# Altium schematic sheet ATMega328P_weather_station_MCU.SchDoc(ATMega328P_weather_station_MCU)
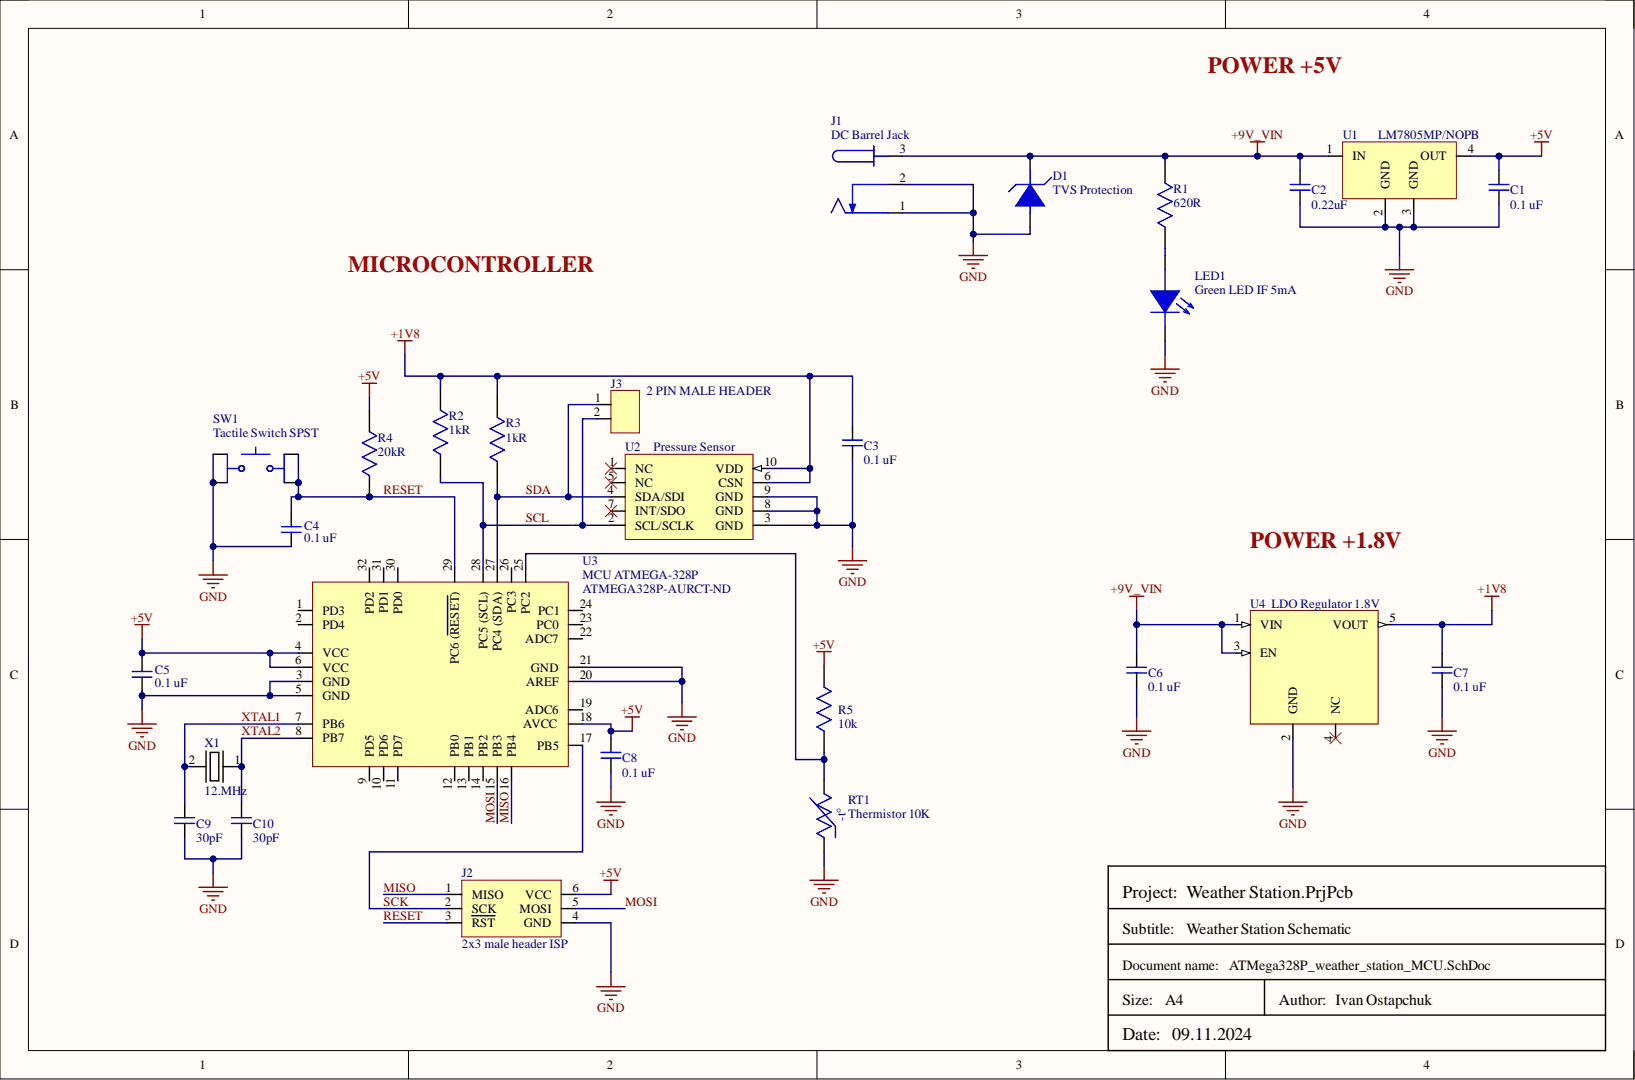
[diagram p0: ATMega328P_weather_station_MCU.SchDoc]

## Parts
C1  0.1 uF  [1210B104K500CT]
C2  0.22uF  [Ceramic Cap 0.22uF 1210 Low density]
C3  0.1 uF  [1210B104K500CT]
C4  0.1 uF  [1210B104K500CT]
C5  0.1 uF  [1210B104K500CT]
C6  0.1 uF  [1210B104K500CT]
C7  0.1 uF  [1210B104K500CT]
C8  0.1 uF  [1210B104K500CT]
C9  30pF  [Kyocera 1210 Ceramic Capacitor]
C10  30pF  [Kyocera 1210 Ceramic Capacitor]
D1  TVS Protection  [Unidirectional TVS protection diode]
J1  DC Barrel Jack  [Power Barrel Jack]
J2  2x3 male header ISP  [2x3 male header ISP]
J3  2 PIN MALE HEADER  [2 pin male header smd]
LED1  Green LED IF 5mA  [SMD LED]
R1  620R  [1206 WIDE SMD Resistor]
R2  1kR  [Yageo 1206 SMD Resistor]
R3  1kR  [Yageo 1206 SMD Resistor]
R4  20kR  [Yageo 1206 SMD Resistor]
R5  10k  [Yageo 1206 SMD Resistor]
RT1  Thermistor 10K  [Yageo 1206 SMD Resistor]
SW1  Tactile Switch SPST  Throw Configuration: SPST  [Tactile Switch]
U1  Linear V Regulator  [LM7805MP/NOPB]  (value not drawn)
U2  Pressure Sensor  [XDCR_ENS220S-BLGT]
U3  MCU ATMEGA-328P  Supplier Part Number 1: ATMEGA328P-AURCT-ND  [QFP80P900X900X120-32N]
U4  LDO Regulator 1.8V  [LDO 1.8V]
X1  12.MHz  [ABLS-12.000MHZ-20-B-3-H-T]

## Nets
+1V8: C3.2 C7.1 R2.1 R3.1 U2.6(CSN) U2.10(VDD) U4.5(VOUT)
+5V: C1.1 C5.2 C8.2 J2.6(VCC) R4.1 R5.1 U1.4(OUT) U3.4(VCC) U3.6(VCC) U3.18(AVCC)
+9V_VIN: C2.1 C6.1 D1.2 J1.3 R1.2 U1.1(IN) U4.1(VIN) U4.3(EN)
GND: C1.2 C2.2 C3.1 C4.1 C5.1 C6.2 C7.2 C8.1 C9.1 C10.1 D1.1 J1.1 J1.2 J2.4(GND) LED1.2 RT1.1 SW1.1 SW1.3 U1.2(GND) U1.3(GND) U2.3(GND) U2.8(GND) U2.9(GND) U3.3(GND) U3.5(GND) U3.20(AREF) U3.21(GND) U4.2(GND)
MISO: J2.1(MISO) U3.16(PB4)
MOSI: J2.5(MOSI) U3.15(PB3)
RESET: C4.2 J2.3(RST) R4.2 SW1.2 SW1.4 U3.29(PC6_(RESET))
SCK: J2.2(SCK) U3.17(PB5)
SCL: J3.2 R2.2 U2.2(SCL/SCLK) U3.28(PC5_(SCL))
SDA: J3.1 R3.2 U2.4(SDA/SDI) U3.27(PC4_(SDA))
XTAL1: C9.2 U3.7(PB6) X1.2
XTAL2: C10.2 U3.8(PB7) X1.1
NetLED1_1: LED1.1 R1.1
NetR5_2: R5.2 RT1.2 U3.25(PC2)

Not connected: U2 1(NC) 5(NC) 7(INT/SDO); U3 1(PD3) 2(PD4) 9(PD5) 10(PD6) 11(PD7) 12(PB0) 13(PB1) 14(PB2) 19(ADC6) 22(ADC7) 23(PC0) 24(PC1) 26(PC3) 30(PD0) 31(PD1) 32(PD2); U4 4(NC)

## Library data of the parts
C1 C3-C8 = Ceramic Cap, 0.1 uF, 10%, 50 V, 1210: CerCapacitor, 0.1 uF, 10%, X7R, 50 V, 1210 [3225 Metric] Rohs Compliant: Yes |Walsin 1210B104K500CT; Manufacturer: Walsin Technologies; Capacitance: 100nF; Voltage Rating: 50V; Case Code (Imperial): 1210; Case Code (Metric): 3225; Case/Package: Ceramic; Category: Ceramic Capacitors; Component Kind: Standard; ComponentLink1Description: https://datasheet.ciiva.com/26864/getdatasheetpartid-414729-26864951.pdf?src-supplier=Verical; ComponentLink1URL: https://datasheet.ciiva.com/26864/getdatasheetpartid-414729-26864951.pdf?src-supplier=Verical; Depth: 2.5mm; Dielectric: X7R; Lead Free: 5697LeadFree; Length: 3.2mm; Manufacturer Part Number: 1210B104K500CT; Max Operating Temperature: 125°C; Min Operating Temperature: -55°C; Packaging: Tape and Reel; Pricing 1: 1=0,23, 10=0,133, 50=0,0944, 100=0,0824, 500=0,06194, 1000=0,05558 (USD); Radiation Hardening: No; Reach SVHC Compliant: Unknown; RoHS: Yes; RoHS Compliant: Yes; Stock 1: 2921; Supplier 1: Digikey; Supplier Part Number 1: 1292-1618-6-ND; Tolerance: 10%; Voltage Rating (DC): 50V; Library Name: Weather_Schlib.SchLib
C2 = Ceramic Cap, 0.22uF, 10%, 50V, 1210: Multilayer Ceramic Capacitors MLCC - SMD/SMT 50V 0.22uF X7R 1210 10%; Manufacturer: KEMET; Manufacturer Part Number: C1210C224K5RACTU; Capacitance: 220nF; Case Code (Imperial): 1210; Case Code (Metric): 3225; Case/Package: 1210; Category: Ceramic Capacitors; Component Kind: Standard; ComponentLink1Description: https://datasheet.ciiva.com/5969/kem-c1002-x7r-smd-5969839.pdf?src-supplier=Farnell; ComponentLink1URL: https://datasheet.ciiva.com/5969/kem-c1002-x7r-smd-5969839.pdf?src-supplier=Farnell; ComponentLink2Description: https://datasheet.ciiva.com/7807/kem-c1002-x7r-smd-7807526.pdf?src-supplier=Element14; ComponentLink2URL: https://datasheet.ciiva.com/7807/kem-c1002-x7r-smd-7807526.pdf?src-supplier=Element14; ComponentLink3Description: https://datasheet.ciiva.com/28682/1851736-28682447.pdf?src-supplier=Element14; ComponentLink3URL: https://datasheet.ciiva.com/28682/1851736-28682447.pdf?src-supplier=Element14; Construction: Flat; Depth: 2.5mm; Dielectric: X7R; Dielectric Material: Ceramic; Height: 0.9mm; Lead Free: 5697LeadFree; Length: 3.2mm; Max Operating Temperature: 125°C; Min Operating Temperature: -55°C; Mount: Surface Mount,PCB; Number of Pins: 2; Package Quantity: 1; Packaging: Tape and Reel; Pricing 1: 1=0,26, 10=0,169, 50=0,1242, 100=0,1012, 500=0,07988, 1000=0,06745 (USD); Radiation Hardening: No; Reach SVHC Compliant: No; RoHS: Yes; RoHS Compliant: Yes; Series: C; Stock 1: 44512; Supplier 1: Digikey; Supplier Part Number 1: 399-C1210C224K5RAC7800DKR-ND; Termination: SMD/SMT; Thickness: 0.039inch; Tolerance: 10%; Voltage: 50V; Voltage Rating: 50V; Voltage Rating (DC): 50V; Width: 0.098inch; Library Name: Weather_Schlib.SchLib
C9 C10 = Ceramic Cap, 30pF, ±5%, 200V, 1210: 30 pF ±5% 200V Ceramic Capacitor C0G, NP0 1210 (3225 Metric); Manufacturer: Kyocera AVX; Manufacturer Part Number: KGQ32DCG2D300JT; Component Kind: Standard; Pricing 1: 1=0,65, 10=0,39, 50=0,2872, 100=0,2553, 500=0,20086, 1000=0,18387 (USD); RoHS: No; Stock 1: 5835; Supplier 1: Digikey; Supplier Part Number 1: 478-KGQ32DCG2D300JTCT-ND; Library Name: Weather_Schlib.SchLib
D1 = Unidirectional TVS protection Diode: Unidirectional TVS protection. SMAJ Series 10 V 400 W SMT Uni-Directional Transient Voltage Suppressor - SMA; Manufacturer: Littelfuse; Manufacturer Part Number: SMAJ10A; Category: TVS Diodes; Component Kind: Standard; ComponentLink1Description: https://datasheet.ciiva.com/330/littelfuse-tvs-diode-smaj-datasheet-pdf-330790.pdf?src-supplier=Digikey; ComponentLink1URL: https://datasheet.ciiva.com/330/littelfuse-tvs-diode-smaj-datasheet-pdf-330790.pdf?src-supplier=Digikey; ComponentLink2Description: https://datasheet.ciiva.com/330/littelfuse-tvs-diode-smaj-datasheet-pdf-330791.pdf?src-supplier=Digikey; ComponentLink2URL: https://datasheet.ciiva.com/330/littelfuse-tvs-diode-smaj-datasheet-pdf-330791.pdf?src-supplier=Digikey; ComponentLink3Description: https://datasheet.ciiva.com/330/littelfuse-tvs-diode-smaj-datasheet-pdf-330792.pdf?src-supplier=Digikey; ComponentLink3URL: https://datasheet.ciiva.com/330/littelfuse-tvs-diode-smaj-datasheet-pdf-330792.pdf?src-supplier=Digikey; ComponentLink4Description: https://datasheet.ciiva.com/8062/littelfuse-tvs-diode-smaj-8062262.pdf?src-supplier=Element14; ComponentLink4URL: https://datasheet.ciiva.com/8062/littelfuse-tvs-diode-smaj-8062262.pdf?src-supplier=Element14; Pricing 1: 1=0,4, 10=0,287, 100=0,1449, 500=0,12844, 1000=0,09996, 2000=0,08947 (USD); RoHS: Yes; Stock 1: 44201; Supplier 1: Digikey; Supplier Part Number 1: SMAJ10ALFCT-ND; Library Name: Weather_Schlib.SchLib
J1 = Barrel Connector Jack: Power Barrel Connector Jack 2.05mm ID (0.081"), 5.50mm OD (0.217") Surface Mount, Right Angle; Manufacturer: Global Connector Technology; Manufacturer Part Number: DCJ200-05-A-K1-A; Category: Connectors; Component Kind: Standard; ComponentLink1Description: https://datasheet.octopart.com/DCJ200-05-A-K1-A-Global-Connector-Technology-datasheet-77992270.pdf?src-supplier=Future+Electronics; ComponentLink1URL: https://datasheet.octopart.com/DCJ200-05-A-K1-A-Global-Connector-Technology-datasheet-77992270.pdf?src-supplier=Future+Electronics; ComponentLink2Description: https://gct.co/files/drawings/dcj200-05.pdf?src-supplier=Digikey; ComponentLink2URL: https://gct.co/files/drawings/dcj200-05.pdf?src-supplier=Digikey; ComponentLink3Description: https://datasheet.octopart.com/DCJ200-05-A-K1-A-Global-Connector-Technology-datasheet-91940685.pdf?src-supplier=Farnell; ComponentLink3URL: https://datasheet.octopart.com/DCJ200-05-A-K1-A-Global-Connector-Technology-datasheet-91940685.pdf?src-supplier=Farnell; ComponentLink4Description: http://www.farnell.com/cad/1884037.pdf?src-supplier=Element14; ComponentLink4URL: http://www.farnell.com/cad/1884037.pdf?src-supplier=Element14; Pricing 1: 1=1,02, 10=0,859, 25=0,8236, 50=0,806, 100=0,771 (USD); RoHS: Yes; Stock 1: 1981; Supplier 1: Digikey; Supplier Part Number 1: 2073-DCJ200-05-A-K1-ACT-ND; Library Name: Weather_Schlib.SchLib
J2 = 2x3 male header ISP: Bs Dr Vt SMT Hdr 2X3 TR-10125394-T0803ALF | Amphenol Fci (amphenol Cs) 10125394T0803ALF; Manufacturer: Amphenol Communications Solutions; Category: Backplane Connectors; Component Kind: Standard; Manufacturer Part Number: 10125394-T0803ALF; Pricing 1: 1=0,66, 10=0,5 (USD); RoHS: No; Stock 1: 2253; Supplier 1: Digikey; Library Name: Weather_Schlib.SchLib
J3 = 2 pin male header: Conn Unshrouded Header HDR 2 POS 2.54mm Solder ST SMD Automotive T/R; Manufacturer: Samtec; Manufacturer Part Number: TSM-102-01-T-SV-TR; Category: Headers and Wire Housings; Component Kind: Standard; ComponentLink1Description: http://www.farnell.com/datasheets/1927672.pdf?src-supplier=Farnell; ComponentLink1URL: http://www.farnell.com/datasheets/1927672.pdf?src-supplier=Farnell; ComponentLink2Description: https://datasheet.octopart.com/TSM-102-01-T-SV-TR-Samtec-datasheet-41643181.pdf?src-supplier=Newark; ComponentLink2URL: https://datasheet.octopart.com/TSM-102-01-T-SV-TR-Samtec-datasheet-41643181.pdf?src-supplier=Newark; ComponentLink3Description: http://suddendocs.samtec.com/catalog_english/tsm.pdf?src-supplier=Digikey; ComponentLink3URL: http://suddendocs.samtec.com/catalog_english/tsm.pdf?src-supplier=Digikey; ComponentLink4Description: http://www.samtec.com/documents/webfiles/pdf/TSM.PDF?src-supplier=Avnet; ComponentLink4URL: http://www.samtec.com/documents/webfiles/pdf/TSM.PDF?src-supplier=Avnet; ComponentLink5Description: https://datasheet.octopart.com/TSM-102-01-T-SV-TR-Samtec-datasheet-97319594.pdf?src-supplier=Sager+Electronics; ComponentLink5URL: https://datasheet.octopart.com/TSM-102-01-T-SV-TR-Samtec-datasheet-97319594.pdf?src-supplier=Sager+Electronics; ComponentLink6Description: https://datasheet.octopart.com/TSM-102-01-T-SV-TR-Samtec-datasheet-116533474.pdf?src-supplier=Toby+Electronics; ComponentLink6URL: https://datasheet.octopart.com/TSM-102-01-T-SV-TR-Samtec-datasheet-116533474.pdf?src-supplier=Toby+Electronics; ComponentLink7Description: https://datasheet.octopart.com/TSM-102-01-T-SV-TR-Samtec-datasheet-141160580.pdf?src-supplier=Master+Electronics; ComponentLink7URL: https://datasheet.octopart.com/TSM-102-01-T-SV-TR-Samtec-datasheet-141160580.pdf?src-supplier=Master+Electronics; Pricing 1: 1=0,22 (USD); RoHS: Yes; Stock 1: 4232; Supplier 1: Digikey; Supplier Part Number 1: SAM14779DKR-ND; Library Name: Weather_Schlib.SchLib
LED1 = Green LED IF 5mA: Side View / 0805 / 2.1 X 1.0 X 0; Manufacturer: Inolux; Manufacturer Part Number: IN-S85CS5G; Category: LEDs; Component Kind: Standard; Pricing 1: 1=0,25, 10=0,166, 100=0,1109, 500=0,07668, 1000=0,0639 (USD); RoHS: No; Stock 1: 4626; Supplier 1: Digikey; Supplier Part Number 1: 1830-IN-S85CS5GDKR-ND; Library Name: Weather_Schlib.SchLib
R1 = SMD Resistor 620 Ohms, 1.5W 1206 WIDE: 620 Ohms ±1% 1.5W Chip Resistor Wide 1206 (3216 Metric), 0612 Automotive AEC-Q200, Pulse Withstanding Thick Film; Manufacturer: Rohm; Manufacturer Part Number: LTR18EZPF6200; Max Power Dissipation: 750mW; Temperature Coefficient: 100ppm/°C; Voltage Rating: 200V; Case Code (Imperial): 1206; Case Code (Metric): 3216; Case/Package: 0612; Category: Chip SMD Resistors; Component Kind: Standard; ComponentLink1Description: https://datasheet.ciiva.com/2470/ltr-2470303.pdf?src-supplier=Digikey; ComponentLink1URL: https://datasheet.ciiva.com/2470/ltr-2470303.pdf?src-supplier=Digikey; ComponentLink2Description: https://datasheet.ciiva.com/2470/ltr-2470304.pdf?src-supplier=Digikey; ComponentLink2URL: https://datasheet.ciiva.com/2470/ltr-2470304.pdf?src-supplier=Digikey; ComponentLink3Description: https://datasheet.ciiva.com/2470/ltr-2470305.pdf?src-supplier=Digikey; ComponentLink3URL: https://datasheet.ciiva.com/2470/ltr-2470305.pdf?src-supplier=Digikey; Features: Automotive AEC-Q200; Max Operating Temperature: 155°C; Min Operating Temperature: -55°C; Number of Terminations: 2; Packaging: Cut Tape; Pricing 1: 1=0,2, 10=0,107, 50=0,073, 100=0,0629, 500=0,04618, 1000=0,0409 (USD); Ratings: AEC-Q200; Resistance: 620R; RoHS: No; RoHS Compliant: No; Series: LTR; Stock 1: 8335; Supplier 1: Digikey; Supplier Part Number 1: RHM620YCT-ND; Tolerance: 1%; Library Name: Weather_Schlib.SchLib
R2 R3 = SMD Resistor 1 kOhm, 250mW, 1206: SMD Chip Resistor, 1 kOhm, ± 1%, 250 mW, 1206 [3216 Metric], Thick Film, General Purpose; Manufacturer: Yageo; Manufacturer Part Number: RC1206FR-071KL; Power Rating: 250mW; Temperature Coefficient: 100ppm/°C; Voltage Rating: 200V; Case Code (Imperial): 1206; Case Code (Metric): 3216; Case/Package: 1206; Category: Chip SMD Resistors; Component Kind: Standard; Depth: 1.6mm; Height: 0.55mm; Lead Free: Lead Free; Length: 3.1mm; Max Operating Temperature: 155°C; Min Operating Temperature: -55°C; Packaging: Tape and Reel; Pricing 1: 1=0,1, 10=0,038, 50=0,0244, 100=0,0206, 500=0,01434, 1000=0,01248 (USD); Reach SVHC Compliant: Unknown; Resistance: 1kR; Resistance Tolerance: 1%; Resistor Type: Thick Film; RoHS: Yes; RoHS Compliant: Yes; Series: RC; Size Code: 1206; Stock 1: 367793; Supplier 1: Digikey; Supplier Part Number 1: 311-1.00KFRDKR-ND; Technology: THICK FILM; Termination: SMD/SMT; Tolerance: 1%; Voltage Rating (AC): 200V; Library Name: Weather_Schlib.SchLib
R4 = SMD Resistor, 20k Ohm, 250mW, 1206: 20 kOhm, ± 1%, 250 mW, SMD Resistor, 1206 [3216 Metric], Thick Film, General Purpose; Manufacturer: Yageo; Manufacturer Part Number: RC1206FR-0720KL; Voltage Rating (DC): 200V; Category: Chip SMD Resistors; Component Kind: Standard; ComponentLink1Description: http://www.yageo.com/documents/recent/PYu-RC_Group_51_RoHS_L_9.pdf?src-supplier=Digikey; ComponentLink1URL: http://www.yageo.com/documents/recent/PYu-RC_Group_51_RoHS_L_9.pdf?src-supplier=Digikey; ComponentLink2Description: http://www.yageo.com/documents/recent/PYu-RC_Group_51_RoHS_L_10.pdf?src-supplier=Digikey; ComponentLink2URL: http://www.yageo.com/documents/recent/PYu-RC_Group_51_RoHS_L_10.pdf?src-supplier=Digikey; Lead Free: 5697LeadFree; Pricing 1: 1=0,1, 10=0,038, 50=0,0244, 100=0,0206, 500=0,01434, 1000=0,01248 (USD); RoHS: Yes; Stock 1: 254185; Supplier 1: Digikey; Supplier Part Number 1: 311-20.0KFRCT-ND; Library Name: Weather_Schlib.SchLib
R5 = SMD Resistor, 10 kOhm, 250 mW, 1206: SMD Chip Resistor, 10 kOhm, 1%, 250 mW, 1206 [3216 Metric], Thick Film, General Purpose; Manufacturer: Yageo; Manufacturer Part Number: RC1206FR-0710KL; Max Power Dissipation: 250mW; Resistance: 10kR; Temperature Coefficient: 100ppm/°C; Voltage Rating: 200V; Case Code (Imperial): 1206; Case Code (Metric): 3216; Case/Package: 1206; Category: Chip SMD Resistors; Component Kind: Standard; ComponentLink1Description: https://datasheet.ciiva.com/46445/444357-46445703.pdf?src-supplier=Element14; ComponentLink1URL: https://datasheet.ciiva.com/46445/444357-46445703.pdf?src-supplier=Element14; ComponentLink2Description: https://datasheet.ciiva.com/7857/1692832-7857244.pdf?src-supplier=Element14; ComponentLink2URL: https://datasheet.ciiva.com/7857/1692832-7857244.pdf?src-supplier=Element14; ComponentLink3Description: https://datasheet.ciiva.com/7857/1692832-7857245.pdf?src-supplier=Element14; ComponentLink3URL: https://datasheet.ciiva.com/7857/1692832-7857245.pdf?src-supplier=Element14; Features: Moisture Resistant; Height: 0.55mm; Lead Free: 5697LeadFree; Length: 3.1mm; Max Operating Temperature: 155°C; Min Operating Temperature: -55°C; Number of Terminations: 2; Package Quantity: 5000; Packaging: Tape and Reel; Power Rating: 250mW; Pricing 1: 1=0,1, 10=0,038, 50=0,0244, 100=0,0206, 500=0,01434, 1000=0,01248 (USD); Reach SVHC Compliant: Unknown; Resistance Tolerance: 1%; Resistor Type: Thick Film; RoHS: Yes; RoHS Compliant: Yes; Series: RC; Size Code: 1206; Stock 1: 2825737; Supplier 1: Digikey; Supplier Part Number 1: 311-10.0KFRCT-ND; Termination: SMD/SMT; Tolerance: 1%; Voltage Rating (AC): 200V; Width: 1.6mm; Library Name: Weather_Schlib.SchLib
RT1 = Thermistor NTC 10K: Thermistor NTC 10K Ohm 10% 2-Pin 1206 Surface Mount Solder Pad 3510K Blister T/R; Manufacturer: TDK EPCOS; Manufacturer Part Number: B57621C5103K062; Power Rating: 300mW; Resistance: 10kR; Case/Package: 1206; Category: Thermistors; Component Kind: Standard; ComponentLink1Description: https://datasheet.ciiva.com/15097/getdatasheetpartid-382188-15097872.pdf?src-supplier=Verical; ComponentLink1URL: https://datasheet.ciiva.com/15097/getdatasheetpartid-382188-15097872.pdf?src-supplier=Verical; Depth: 1.6mm; Height: 1.3mm; Length: 3.2mm; Max Operating Temperature: 125°C; Min Operating Temperature: -55°C; Number of Pins: 2; Package Quantity: 4000; Packaging: Tape and Reel; Pricing 1: 1=1,17, 10=0,878, 25=0,7024, 100=0,6439, 500=0,5415, 1000=0,48295 (USD); Radiation Hardening: No; RoHS: Yes; RoHS Compliant: Yes; Stock 1: 7290; Supplier 1: Digikey; Supplier Part Number 1: 495-B57621C5103K062CT-ND; Termination: SMD/SMT; Tolerance: 10%; Weight: 0.000635oz; Width: 1.6mm; Library Name: Weather_Schlib.SchLib
SW1 = Tactile Switch SPST: TL3305A Series SPST 12 V 4.5 x 4.5 mm 260 gf Top Actuated SMT Tactile Switch; Manufacturer: E-Switch; Manufacturer Part Number: TL3305AF260QG; Actuator Type: Standard; Illumination Type: Non-Illuminated; Mechanical Life: 200000Cycles; Operating Force: 260gf; Switch Function: Off-Mom; Switch Travel: 0.2mm; Category: Tactile Switches; Component Kind: Standard; ComponentLink1Description: http://www.farnell.com/datasheets/2554191.pdf?src-supplier=Farnell; ComponentLink1URL: http://www.farnell.com/datasheets/2554191.pdf?src-supplier=Farnell; ComponentLink2Description: http://www.farnell.com/datasheets/2554191.pdf?src-supplier=Newark; ComponentLink2URL: http://www.farnell.com/datasheets/2554191.pdf?src-supplier=Newark; ComponentLink3Description: http://spec_sheets.e-switch.com/specs/P010471.pdf?src-supplier=Mouser; ComponentLink3URL: http://spec_sheets.e-switch.com/specs/P010471.pdf?src-supplier=Mouser; ComponentLink4Description: http://www.farnell.com/datasheets/2554191.pdf?src-supplier=Element14; ComponentLink4URL: http://www.farnell.com/datasheets/2554191.pdf?src-supplier=Element14; ComponentLink5Description: http://spec_sheets.e-switch.com/specs/P010471.pdf?src-supplier=Digikey; ComponentLink5URL: http://spec_sheets.e-switch.com/specs/P010471.pdf?src-supplier=Digikey; ComponentLink6Description: http://spec_sheets.e-switch.com/specs/P010471.pdf?src-supplier=NAC+Semi; ComponentLink6URL: http://spec_sheets.e-switch.com/specs/P010471.pdf?src-supplier=NAC+Semi; Lead Free: Lead Free; Max Operating Temperature: 70°C; Min Operating Temperature: -20°C; Mount: Surface Mount; Packaging: Cut Tape; Pricing 1: 1=0,21, 10=0,205, 25=0,1944, 100=0,176, 250=0,16048, 500=0,14918, 1000=0,12726 (USD); RoHS: No; Series: TL3305; Stock 1: 157478; Supplier 1: Digikey; Supplier Part Number 1: EG5353DKR-ND; Termination: Gull Wing; Library Name: Weather_Schlib.SchLib
U1 = Linear Voltage Regulator LM7805MP/NOPB: 1.5-A, 30-V, linear voltage regulator 4-SOT-223 0 to 125; Manufacturer: Texas Instruments; Accuracy: 3%; Dropout Voltage: 2V; Max Supply Voltage: 30V; Min Supply Voltage: 7.5V; Nominal Supply Current: 8mA; Number of Outputs: 1NUMBER; Output Voltage: 5V; Category: Voltage Regulators - Linear; Component Kind: Standard; ComponentLink1Description: http://www.ti.com/general/docs/suppproductinfo.tsp?distId=10&amp;gotoUrl=http%3A%2F%2Fwww.ti.com%2Flit%2Fgpn%2Flm340&src-supplier=Digikey; ComponentLink1URL: http://www.ti.com/general/docs/suppproductinfo.tsp?distId=10&amp;gotoUrl=http%3A%2F%2Fwww.ti.com%2Flit%2Fgpn%2Flm340&src-supplier=Digikey; Height: 1.8mm; Lead Free: 5698LeadFree; Length: 6.5mm; Manufacturer Part Number: LM7805MP/NOPB; Number of Pins: 4NUMBER; Pricing 1: 1=1,73, 10=1,555, 25=1,4672, 100=1,2499, 250=1,1736, 500=1,02692 (USD); RoHS: Yes; Stock 1: 2585; Supplier 1: Digikey; Supplier Part Number 1: 296-44517-1-ND; Thickness: 1.6mm; Width: 3.5mm; Library Name: Weather_Schlib.SchLib
U2 = Pressure Sensor: Pressure Sensor 4.35PSI ~ 17.4PSI (30kPa ~ 120kPa) Absolute 24 b 10-LGA; Manufacturer: ScioSense; Manufacturer Part Number: ENS220S-BLGT; Component Kind: Standard; Pricing 1: 1=3,45, 10=2,591, 25=2,3028, 50=2,1876, 100=2,1301, 500=1,78468, 1000=1,66953 (USD); RoHS: No; Stock 1: 2645; Supplier 1: Digikey; Supplier Part Number 1: 2618-ENS220S-BLGTCT-ND; Library Name: Weather_Schlib.SchLib
U3 = MCU ATMEGA-328P: AVR series Microcontroller IC 8-Bit 2MHz 32KB (16K x 16) FLASH 32-TQFP (7x7); Manufacturer: Microchip; Manufacturer Part Number: ATMEGA328P-AUR; Core Architecture: PIC; Data Bus Width: 8b; Interface: I2C,SPI,UART,USART; Max Frequency: 20MHz; Max Supply Voltage: 5.5V; Min Supply Voltage: 1.8V; Number of ADC Channels: 8NUMBER; Number of I2C Channels: 1NUMBER; Number of I/Os: 23; Number of Programmable I/O: 23NUMBER; Number of PWM Channels: 6NUMBER; Number of SPI Channels: 2NUMBER; Number of Timers/Counters: 3NUMBER; Oscillator Type: Internal; Peripherals: Brown-out Detect/Reset,POR,PWM,WDT; RAM Size: 2KB; Watchdog Timer: 5512WatchdogTimer; Case/Package: TQFP; Category: Microcontrollers; Component Kind: Standard; ComponentLink1Description: http://www.farnell.com/datasheets/2047852.pdf?src-supplier=Farnell; ComponentLink1URL: http://www.farnell.com/datasheets/2047852.pdf?src-supplier=Farnell; ComponentLink2Description: http://components.arrow.com/part/dataview/48719204S8832641N3744?src-supplier=Arrow+Electronics; ComponentLink2URL: http://components.arrow.com/part/dataview/48719204S8832641N3744?src-supplier=Arrow+Electronics; ComponentLink3Description: http://www.produktinfo.conrad.com/datenblaetter/1200000-1299999/001267077-da-01-en-IC_MCU_8BIT_3_ATMEGA328P_AUR_TQFP_32_ATM.pdf?src-supplier=Conrad; ComponentLink3URL: http://www.produktinfo.conrad.com/datenblaetter/1200000-1299999/001267077-da-01-en-IC_MCU_8BIT_3_ATMEGA328P_AUR_TQFP_32_ATM.pdf?src-supplier=Conrad; ComponentLink4Description: https://datasheet.octopart.com/ATMEGA328P-AUR-Microchip-datasheet-14421303.pdf?src-supplier=Newark; ComponentLink4URL: https://datasheet.octopart.com/ATMEGA328P-AUR-Microchip-datasheet-14421303.pdf?src-supplier=Newark; ComponentLink5Description: http://www.farnell.com/datasheets/2047852.pdf?src-supplier=Element14; ComponentLink5URL: http://www.farnell.com/datasheets/2047852.pdf?src-supplier=Element14; ComponentLink6Description: https://datasheet.octopart.com/ATMEGA328P-AUR-Microchip-datasheet-101746195.pdf?src-supplier=Future+Electronics; ComponentLink6URL: https://datasheet.octopart.com/ATMEGA328P-AUR-Microchip-datasheet-101746195.pdf?src-supplier=Future+Electronics; ComponentLink7Description: http://www.farnell.com/datasheets/1693866.pdf?src-supplier=Newark; ComponentLink7URL: http://www.farnell.com/datasheets/1693866.pdf?src-supplier=Newark; ComponentLink8Description: http://www.microchip.com/mymicrochip/filehandler.aspx?ddocname=en589968&src-supplier=Digikey; ComponentLink8URL: http://www.microchip.com/mymicrochip/filehandler.aspx?ddocname=en589968&src-supplier=Digikey; ComponentLink9Description: http://www.microchip.com/mymicrochip/filehandler.aspx?ddocname=en608326&src-supplier=Digikey; ComponentLink9URL: http://www.microchip.com/mymicrochip/filehandler.aspx?ddocname=en608326&src-supplier=Digikey; ComponentLink10Description: https://datasheet.octopart.com/ATMEGA328P-AUR-Microchip-datasheet-133520744.pdf?src-supplier=LKR; ComponentLink10URL: https://datasheet.octopart.com/ATMEGA328P-AUR-Microchip-datasheet-133520744.pdf?src-supplier=LKR; ComponentLink11Description: https://datasheet.octopart.com/ATMEGA328P-AUR-Microchip-datasheet-154741723.pdf?src-supplier=Electro+Sonic; ComponentLink11URL: https://datasheet.octopart.com/ATMEGA328P-AUR-Microchip-datasheet-154741723.pdf?src-supplier=Electro+Sonic; ComponentLink12Description: https://datasheet.octopart.com/ATMEGA328P-AUR-Microchip-datasheet-68295846.pdf?src-supplier=SHENGYU+ELECTRONICS; ComponentLink12URL: https://datasheet.octopart.com/ATMEGA328P-AUR-Microchip-datasheet-68295846.pdf?src-supplier=SHENGYU+ELECTRONICS; Contact Plating: 154ConductorMaterial; Height: 1.2mm; Lead Free: 5697LeadFree; Max Operating Temperature: 85°C; Memory Size: 32KB; Memory Type: FLASH; Min Operating Temperature: -40°C; Mount: Surface Mount; Number of Pins: 32; Number of UART Channels: 1NUMBER; Packaging: Cut Tape; Pricing 1: 1=2,74, 25=2,54, 100=2,3 (USD); Reach SVHC Compliant: 4186SVHC; RoHS: Yes; RoHS Compliant: Yes; Series: PIC® 18F; Stock 1: 111026; Supplier 1: Digikey; Library Name: Weather_Schlib.SchLib
U4 = LDO Regulator 1.8V: Linear Voltage Regulator IC Positive Fixed 1 Output 1.8V 300mA SOT-23-5; Manufacturer: Microchip; Manufacturer Part Number: MIC5504-1.8YM5-TR; Category: Voltage Regulators - Linear; Component Kind: Standard; ComponentLink1Description: http://www.microchip.com/mymicrochip/filehandler.aspx?ddocname=en580292&src-supplier=Digikey; ComponentLink1URL: http://www.microchip.com/mymicrochip/filehandler.aspx?ddocname=en580292&src-supplier=Digikey; ComponentLink2Description: http://ww1.microchip.com/downloads/en/DeviceDoc/MIC550X.pdf?src-supplier=Farnell; ComponentLink2URL: http://ww1.microchip.com/downloads/en/DeviceDoc/MIC550X.pdf?src-supplier=Farnell; ComponentLink3Description: https://datasheet.octopart.com/MIC5504-1.8YM5-TR-Microchip-datasheet-137404938.pdf?src-supplier=Future+Electronics; ComponentLink3URL: https://datasheet.octopart.com/MIC5504-1.8YM5-TR-Microchip-datasheet-137404938.pdf?src-supplier=Future+Electronics; ComponentLink4Description: http://ww1.microchip.com/downloads/en/DeviceDoc/MIC550X.pdf?src-supplier=Newark; ComponentLink4URL: http://ww1.microchip.com/downloads/en/DeviceDoc/MIC550X.pdf?src-supplier=Newark; ComponentLink5Description: https://datasheet.octopart.com/MIC5504-1.8YM5-TR-Microchip-datasheet-137404938.pdf?src-supplier=Electro+Sonic; ComponentLink5URL: https://datasheet.octopart.com/MIC5504-1.8YM5-TR-Microchip-datasheet-137404938.pdf?src-supplier=Electro+Sonic; Pricing 1: 1=0,16, 25=0,12, 100=0,11 (USD); RoHS: Yes; Stock 1: 215403; Supplier 1: Digikey; Supplier Part Number 1: 576-4879-6-ND; Library Name: Weather_Schlib.SchLib
X1 = ABLS-12.000MHZ-20-B-3-H-T: Crystal 12MHz ±25ppm (Tol) ±35ppm (Stability) 20pF FUND 50Ohm 2-Pin HC-49/US SMD T/R; Manufacturer: Abracon; Category: Crystals; Component Kind: Standard; ComponentLink1Description: https://abracon.com/Resonators/abls.pdf?src-supplier=Digikey; ComponentLink1URL: https://abracon.com/Resonators/abls.pdf?src-supplier=Digikey; ComponentLink2Description: https://datasheet.octopart.com/ABLS-12.000MHZ-20-B-3-H-T-Abracon-datasheet-139816269.pdf?src-supplier=Electro+Sonic; ComponentLink2URL: https://datasheet.octopart.com/ABLS-12.000MHZ-20-B-3-H-T-Abracon-datasheet-139816269.pdf?src-supplier=Electro+Sonic; Manufacturer Part Number: ABLS-12.000MHZ-20-B-3-H-T; Pricing 1: 1=0,19, 10=0,16, 50=0,1444, 100=0,1381, 500=0,12446 (USD); RoHS: Yes; Stock 1: 9001; Supplier 1: Digikey; Supplier Part Number 1: 535-15006-1-ND; Library Name: Weather_Schlib.SchLib
